# Altium schematic sheet [04] POWER.SchDoc("[04] POWER")
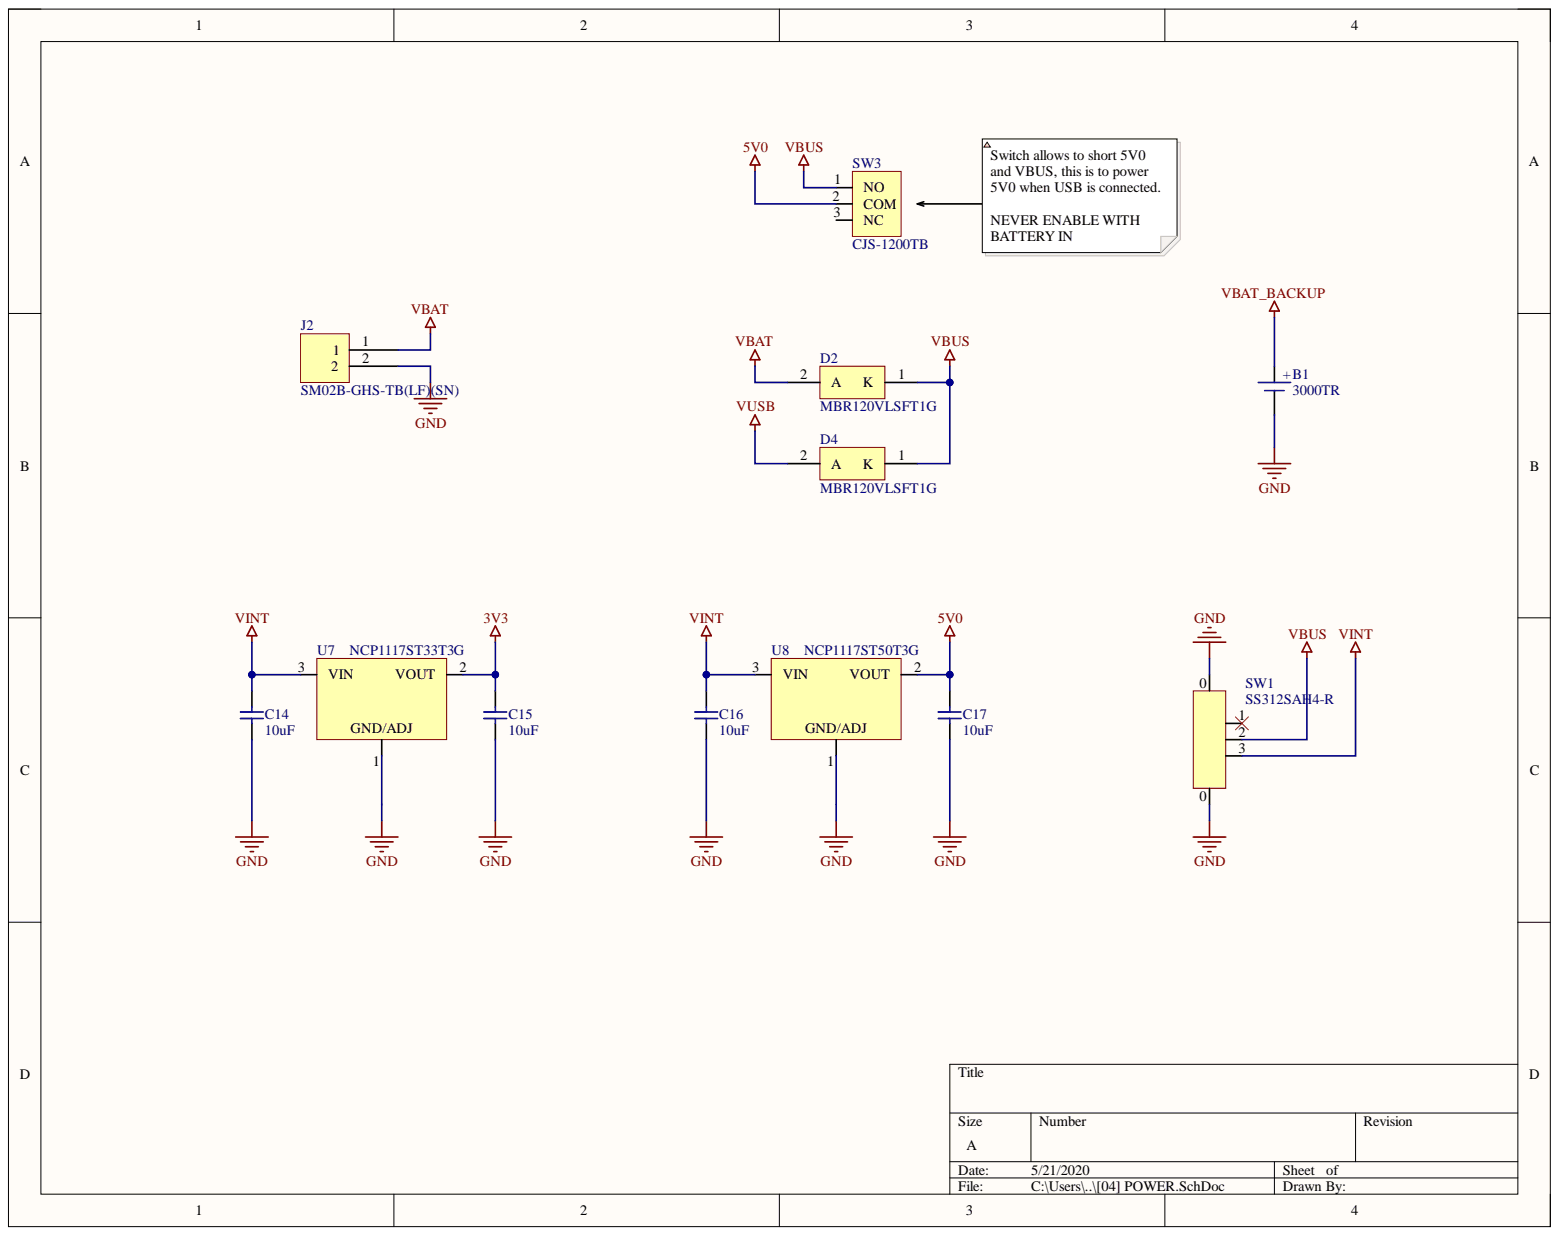
[diagram p0: [04] POWER.SchDoc]

## Parts
B1  3000TR  [3000TR]
C14  10uF  [INCH 0805 - METRIC 2012 - H140]
C15  10uF  [INCH 0805 - METRIC 2012 - H140]
C16  10uF  [INCH 0805 - METRIC 2012 - H140]
C17  10uF  [INCH 0805 - METRIC 2012 - H140]
D2  MBR120VLSFT1G  [ONSC-SOD-123FL-2-498-01_V]
D4  MBR120VLSFT1G  [ONSC-SOD-123FL-2-498-01_V]
J2  SM02B-GHS-TB(LF)(SN)  [SM02B-GHS-TB(LF)(SN)]
SW1  SS312SAH4-R  [NKK_SS312SAH4]
SW3  CJS-1200TB  [NCE_CJS-1200TB]
U7  NCP1117ST33T3G  [SOT223-4P230_700X180L90X70]
U8  NCP1117ST50T3G  [SOT223-4P230_700X180L90X70]

## Nets
3V3: C15.2 U7.2
5V0: C17.2 SW3.2 U8.2
GND: B1.2 C14.1 C15.1 C16.1 C17.1 J2.2 SW1.0 U7.1 U8.1
VBAT: D2.2 J2.1
VBAT_BACKUP: B1.1
VBUS: D2.1 D4.1 SW1.2 SW3.1
VINT: C14.2 C16.2 SW1.3 U7.3 U8.3
VUSB: D4.2

Not connected: SW1 1; SW3 3

## Library data of the parts
B1 = 3000TR: HOLDER BATTERY COIN 12MM DIA SMD; Manufacturer: Keystone Electronics; Connector Type: SMD/SMT; Number of Batteries: 1; Case/Package: SMD/SMT; Category: Power Management ICs; Color: Silver; Component Kind: Standard; ComponentLink1Description: https://datasheet.ciiva.com/3/3000-3936.pdf?src-supplier=Digi-Key; ComponentLink1URL: https://datasheet.ciiva.com/3/3000-3936.pdf?src-supplier=Digi-Key; ComponentLink2Description: https://datasheet.ciiva.com/3/3000tr-3935.pdf?src-supplier=Digi-Key; ComponentLink2URL: https://datasheet.ciiva.com/3/3000tr-3935.pdf?src-supplier=Digi-Key; ComponentLink3Description: https://datasheet.ciiva.com/16305/m60-2p7-16305915.pdf?src-supplier=Future+Electronics; ComponentLink3URL: https://datasheet.ciiva.com/16305/m60-2p7-16305915.pdf?src-supplier=Future+Electronics; ComponentLink4Description: https://datasheet.ciiva.com/3/3000tr-3937.pdf?src-supplier=Digi-Key; ComponentLink4URL: https://datasheet.ciiva.com/3/3000tr-3937.pdf?src-supplier=Digi-Key; Diameter: 12mm; Lead Free: Lead Free; Library Name: Connectors - Batteries.IntLib; Manufacturer Part Number: 3000TR; Material: Bronze; Mount: Surface Mount,PCB; Number of Cells: 1; Package Quantity: 1; Packaging: Tape and Reel; Pricing 1: 1=0.91000, 25=0.79880, 100=0.63390, 250=0.58356, 500=0.54802 (USD); RoHS: Yes; Stock 1: 23941; Supplier 1: Digi-Key; Supplier Part Number 1: 36-3000CT-ND; Termination: SMD/SMT
C14-C17 = GRM21BR61E106MA73L: CAP CER 10UF 25V X5R 0805; Manufacturer: Murata; Tolerance: 20%; Capacitance: 10uF; Case Code (Imperial): 0805; Case Code (Metric): 2012; Case/Package: 0805; Component Kind: Standard; Composition: Ceramic; Depth: 1.25mm; Dielectric: X5R; Height: 1.25mm; Lead Free: Lead Free; Length: 2mm; Library Name: Capacitors.IntLib; Manufacturer Part Number: GRM21BR61E106MA73L; Max Operating Temperature: 85°C; Min Operating Temperature: -55°C; Mount: Surface Mount; Package Quantity: 3000; Packaging: Tape and Reel; Pricing 1: 1=0.27, 10=0.184, 100=0.1044, 500=0.0737, 1000=0.06449 (USD); REACH SVHC: No SVHC; Stock 1: 30000; Supplier 1: Digi-Key; Supplier Part Number 1: 490-10748-1-ND; Termination: SMD/SMT; Thickness: 0.055inch; Voltage Rating: 25V; Voltage Rating (DC): 25V; Weight: 0.000335oz; Width: 0.049inch
D2 D4 = CMP-1055-00449-1: Schottky Power Rectifier, 2-Pin SOD-123, Pb-Free, Tape and Reel; Manufacturer: On Semiconductor; Configuration: Single; IFSM Max (A): 45; Component Kind: Standard; ComponentLink1Description: Manufacturer URL; ComponentLink1URL: http://www.onsemi.com/; ComponentLink2Description: Datasheet; ComponentLink2URL: http://www.onsemi.cn/pub_link/Collateral/MBR120VLSFT1-D.PDF; ComponentLink3Description: Package Specification; ComponentLink3URL: http://www.onsemi.cn/pub_link/Collateral/MBR120VLSFT1-D.PDF; DatasheetVersion: Rev. 2; Library Name: Altium Content Vault; Mounting Technology: Surface Mount; PackageDescription: 2-Pin SOD, Body 1.65 x 2.7 mm; PackageReference: SOD-123FL-2-498-01; PackageVersion: Rev. A; Packing: Tape and Reel; PartNumber: MBR120VLSFT1G; RoHS: TRUE
J2 = SM02B-GHS-TB(LF)(SN): Connector Header, GH Series, 2 Position, 1.25mm, 30-26 AWG, 50V, Surface Mount, Right Angle, Solder, Locking Ramp; Manufacturer: JST; Connector Type: Outer Shroud Contact; Current Rating: 1A; Number of Contacts: 2; Pitch: 0.049inch; Category: Headers and Wire Housings; Color: Natural; Component Kind: Standard; ComponentLink1Description: https://datasheet.ciiva.com/25617/0900766b810cef17-25617252.pdf?src-supplier=RSComponents; ComponentLink1URL: https://datasheet.ciiva.com/25617/0900766b810cef17-25617252.pdf?src-supplier=RSComponents; ComponentLink2Description: https://datasheet.ciiva.com/730/egh-730691.pdf?src-supplier=Digi-Key; ComponentLink2URL: https://datasheet.ciiva.com/730/egh-730691.pdf?src-supplier=Digi-Key; ComponentLink3Description: https://datasheet.ciiva.com/712/egh-712452.pdf?src-supplier=Digi-Key; ComponentLink3URL: https://datasheet.ciiva.com/712/egh-712452.pdf?src-supplier=Digi-Key; ComponentLink4Description: https://datasheet.ciiva.com/16345/jstsm02b-ghs-tb-lf-sn-16345351.pdf?src-supplier=Future+Electronics; ComponentLink4URL: https://datasheet.ciiva.com/16345/jstsm02b-ghs-tb-lf-sn-16345351.pdf?src-supplier=Future+Electronics; Contact Material: Bronze; Contact Plating: Tin; Fastening Type: Ramp; Housing Color: Natural; Housing Material: Nylon; Lead Free: Lead Free; Library Name: Connectors.IntLib; Manufacturer Part Number: SM02B-GHS-TB(LF)(SN); Mount: Surface Mount; Number of Positions: 2; Number of Rows: 1; Orientation: Right Angle; Packaging: Tape and Reel; Pricing 1: 1=0.46000, 10=0.43800, 100=0.30670, 500=0.26292, 1000=0.21910 (USD); RoHS: Yes; Stock 1: 14162; Supplier 1: Digi-Key; Supplier Part Number 1: 455-1564-1-ND; Termination: Solder; Voltage Rating: 50V
SW1 = SS312SAH4-R: SWITCH SLIDE SPDT 0.4VA 28V; Manufacturer: NKK Switches; Actuator Length: 2mm; Actuator Material: Polyphenylene; Actuator Type: Standard; Electrical Life: 10000Cycles; Max Current Rating: 100mA; Switch Function: On-On; Case/Package: SMD/SMT; Component Kind: Standard; ComponentLink1Description: http://www.farnell.com/datasheets/1783587.pdf?src-supplier=Element14; ComponentLink1URL: http://www.farnell.com/datasheets/1783587.pdf?src-supplier=Element14; Contact Material: Bronze; Contact Plating: Gold,Silver; Depth: 7.45mm; Housing Material: Bronze; Lead Free: Lead Free; Length: 10.5mm; Library Name: Switches.IntLib; Manufacturer Part Number: SS312SAH4-R; Max Operating Temperature: 85°C; Max Voltage Rating (AC): 28V; Max Voltage Rating (DC): 28V; Mechanical Life: 10000Cycles; Min Operating Temperature: -25°C; Mount: Surface Mount; Orientation: Right Angle; Package Quantity: 500; Packaging: Tape and Reel; Pricing 1: 1 = 3.33000, 10 = 3.18800, 25 = 3.04920, 50 = 2.70280, 100 = 2.63340, 250 = 2.59876 (USD); REACH SVHC: No SVHC; RoHS: Yes; Stock 1: 6697; Supplier 1: Digi-Key; Supplier Part Number 1: 360-2924-1-ND; Switch Travel: 2mm; Termination: Gull Wing; Throw Configuration: SPDT; Voltage Rating (AC): 28V; Voltage Rating (DC): 28V
SW3 = CJS-1200TB: SWITCH SLIDE SPDT 100MA 6V; Manufacturer: Nidec Copal; Body Material: Polyphenylene; Electrical Life: 200Cycles; Max Voltage Rating (DC): 24V; Number of Positions: 2; Washable: Yes; Component Kind: Standard; ComponentLink1Description: https://datasheet.ciiva.com/2865/cjs-2865057.pdf; ComponentLink1URL: https://datasheet.ciiva.com/2865/cjs-2865057.pdf; ComponentLink2Description: https://datasheet.ciiva.com/2865/cjs-2865058.pdf; ComponentLink2URL: https://datasheet.ciiva.com/2865/cjs-2865058.pdf; ComponentLink3Description: https://datasheet.ciiva.com/2865/cjs-2865059.pdf; ComponentLink3URL: https://datasheet.ciiva.com/2865/cjs-2865059.pdf; Contact Material: Copper Alloy; Contact Plating: Gold; Current Rating: 100mA; Lead Free: Lead Free; Length: 0.212inch; Library Name: Switches.IntLib; Manufacturer Part Number: CJS-1200TB; Max Operating Temperature: 85°C; Min Operating Temperature: -40°C; Mount: Surface Mount; Orientation: Vertical; Packaging: Tape and Reel; Radiation Hardening: No; Stock 1: 0; Supplier 1: Digi-Key; Supplier Part Number 1: 563-1022-1-ND; Termination: Gull Wing; Throw Configuration: SPDT; Voltage Rating (DC): 6V
U7 = NCP1117ST33T3G: IC REG LINEAR 3.3V 1A SOT223; Manufacturer: ON Semiconductor; Accuracy: 1%; Dropout Voltage: 1.07V; Input Bias Current: 6mA; Max Input Voltage: 20V; Max Supply Voltage: 15V; Min Input Voltage: 3.5V; Min Supply Voltage: 4.75V; Number of Outputs: 1; Output Type: Fixed; Polarity: Positive; Quiescent Current: 10mA; Reference Voltage: 1.25V; Case/Package: SOT-223; Component Kind: Standard; ComponentLink1Description: https://datasheet.ciiva.com/3224/ncp1117-d-3224144.pdf?src-supplier=Avnet; ComponentLink1URL: https://datasheet.ciiva.com/3224/ncp1117-d-3224144.pdf?src-supplier=Avnet; ComponentLink2Description: https://datasheet.ciiva.com/22697/1726422-22697602.pdf?src-supplier=Element14; ComponentLink2URL: https://datasheet.ciiva.com/22697/1726422-22697602.pdf?src-supplier=Element14; ComponentLink3Description: https://datasheet.ciiva.com/15111/getdatasheetpartid-82718-15111483.pdf?src-supplier=Verical; ComponentLink3URL: https://datasheet.ciiva.com/15111/getdatasheetpartid-82718-15111483.pdf?src-supplier=Verical; ComponentLink4Description: https://datasheet.ciiva.com/8233/ncp1117-d-8233589.pdf?src-supplier=Avnet; ComponentLink4URL: https://datasheet.ciiva.com/8233/ncp1117-d-8233589.pdf?src-supplier=Avnet; ComponentLink5Description: https://datasheet.ciiva.com/2042/ncp1117-d-2042027.pdf?src-supplier=Digi-Key; ComponentLink5URL: https://datasheet.ciiva.com/2042/ncp1117-d-2042027.pdf?src-supplier=Digi-Key; ComponentLink6Description: https://datasheet.ciiva.com/2042/ncp1117-d-2042028.pdf?src-supplier=Digi-Key; ComponentLink6URL: https://datasheet.ciiva.com/2042/ncp1117-d-2042028.pdf?src-supplier=Digi-Key; ComponentLink7Description: https://datasheet.ciiva.com/2042/ncp1117-d-2042029.pdf?src-supplier=Digi-Key; ComponentLink7URL: https://datasheet.ciiva.com/2042/ncp1117-d-2042029.pdf?src-supplier=Digi-Key; ComponentLink8Description: https://datasheet.ciiva.com/8073/1726422-8073735.pdf?src-supplier=Element14; ComponentLink8URL: https://datasheet.ciiva.com/8073/1726422-8073735.pdf?src-supplier=Element14; ComponentLink9Description: https://datasheet.ciiva.com/28483/1748260-28483307.pdf?src-supplier=Element14; ComponentLink9URL: https://datasheet.ciiva.com/28483/1748260-28483307.pdf?src-supplier=Element14; ComponentLink10Description: https://datasheet.ciiva.com/16382/ncp1117-d-16382636.pdf?src-supplier=Future+Electronics; ComponentLink10URL: https://datasheet.ciiva.com/16382/ncp1117-d-16382636.pdf?src-supplier=Future+Electronics; Contact Plating: Tin; Halogen Free: Halogen Free; Height: 1.69mm; Lead Free: Lead Free; Length: 6.7mm; Library Name: Voltage Regulators - Linear.IntLib; Manufacturer Part Number: NCP1117ST33T3G; Max Operating Temperature: 125°C; Max Output Current: 1A; Max Output Voltage: 3.3V; Min Current Limit: 1A; Min Operating Temperature: 0°C; Min Output Voltage: 3.3V; Mount: Surface Mount; Nominal Output Voltage: 3.3V; Number of Pins: 3; Number of Regulators: 1; Output Current: 1A; Output Voltage: 3.3V; Output Voltage Accuracy: 1%; Package Quantity: 1; Packaging: Tape and Reel; Pricing 1: 1 = 0.52000, 10 = 0.44400, 100 = 0.33150, 500 = 0.26048, 1000 = 0.20129 (USD); Radiation Hardening: No; REACH SVHC: No SVHC; RoHS: Yes; Stock 1: 60671; Supplier 1: Digi-Key; Supplier Part Number 1: NCP1117ST33T3GOSCT-ND; Termination: SMD/SMT; Width: 3.7mm
U8 = NCP1117ST50T3G: IC REG LINEAR 5V 1A SOT223; Manufacturer: ON Semiconductor; Accuracy: 1%; Dropout Voltage: 1.2V; Input Bias Current: 6mA; Max Input Voltage: 20V; Min Input Voltage: 3.5V; Number of Outputs: 1; Output Type: Fixed; Polarity: Positive; Power Rating: 781mW; Quiescent Current: 10mA; Case/Package: SOT-223; Component Kind: Standard; ComponentLink1Description: https://datasheet.ciiva.com/28483/1748260-28483320.pdf?src-supplier=Element14; ComponentLink1URL: https://datasheet.ciiva.com/28483/1748260-28483320.pdf?src-supplier=Element14; ComponentLink2Description: https://datasheet.ciiva.com/2042/ncp1117-d-2042018.pdf?src-supplier=Digi-Key; ComponentLink2URL: https://datasheet.ciiva.com/2042/ncp1117-d-2042018.pdf?src-supplier=Digi-Key; ComponentLink3Description: https://datasheet.ciiva.com/2042/ncp1117-d-2042019.pdf?src-supplier=Digi-Key; ComponentLink3URL: https://datasheet.ciiva.com/2042/ncp1117-d-2042019.pdf?src-supplier=Digi-Key; ComponentLink4Description: https://datasheet.ciiva.com/2042/ncp1117-d-2042020.pdf?src-supplier=Digi-Key; ComponentLink4URL: https://datasheet.ciiva.com/2042/ncp1117-d-2042020.pdf?src-supplier=Digi-Key; ComponentLink5Description: https://datasheet.ciiva.com/25978/ncp1117-d-25978293.pdf?src-supplier=Avnet+Europe; ComponentLink5URL: https://datasheet.ciiva.com/25978/ncp1117-d-25978293.pdf?src-supplier=Avnet+Europe; ComponentLink6Description: https://datasheet.ciiva.com/16491/ncp1117-d-16491895.pdf?src-supplier=Avnet+Asia; ComponentLink6URL: https://datasheet.ciiva.com/16491/ncp1117-d-16491895.pdf?src-supplier=Avnet+Asia; ComponentLink7Description: https://datasheet.ciiva.com/8073/ncp1117-d-8073736.pdf?src-supplier=Element14; ComponentLink7URL: https://datasheet.ciiva.com/8073/ncp1117-d-8073736.pdf?src-supplier=Element14; ComponentLink8Description: https://datasheet.ciiva.com/8233/ncp1117-d-8233593.pdf?src-supplier=Avnet; ComponentLink8URL: https://datasheet.ciiva.com/8233/ncp1117-d-8233593.pdf?src-supplier=Avnet; ComponentLink9Description: https://datasheet.ciiva.com/16209/ncp1117-d-16209561.pdf?src-supplier=Future+Electronics; ComponentLink9URL: https://datasheet.ciiva.com/16209/ncp1117-d-16209561.pdf?src-supplier=Future+Electronics; ComponentLink10Description: https://datasheet.ciiva.com/8231/ncp1117-d-8231068.pdf?src-supplier=Avnet; ComponentLink10URL: https://datasheet.ciiva.com/8231/ncp1117-d-8231068.pdf?src-supplier=Avnet; ComponentLink11Description: https://datasheet.ciiva.com/8073/ncp1117-d-8073902.pdf?src-supplier=Element14; ComponentLink11URL: https://datasheet.ciiva.com/8073/ncp1117-d-8073902.pdf?src-supplier=Element14; Contact Plating: Tin; Halogen Free: Halogen Free; Height: 1.69mm; Lead Free: Lead Free; Length: 6.7mm; Library Name: Voltage Regulators - Linear.IntLib; Manufacturer Part Number: NCP1117ST50T3G; Max Operating Temperature: 125°C; Max Output Current: 1A; Min Current Limit: 1A; Min Operating Temperature: 0°C; Nominal Output Voltage: 5V; Number of Pins: 4; Number of Regulators: 1; Output Current: 1A; Output Voltage: 5V; Output Voltage Accuracy: 1%; Package Quantity: 1; Packaging: Tape and Reel; Pricing 1: 1 = 0.54000, 10 = 0.45800, 100 = 0.34230, 500 = 0.26896, 1000 = 0.20783 (USD); Radiation Hardening: No; REACH SVHC: No SVHC; RoHS: Yes; Stock 1: 20727; Supplier 1: Digi-Key; Supplier Part Number 1: NCP1117ST50T3GOSCT-ND; Tolerance: 1%; Width: 3.7mm
